# Altium schematic sheet IMX7S_CONNECTORS_&_IHM.SchDoc
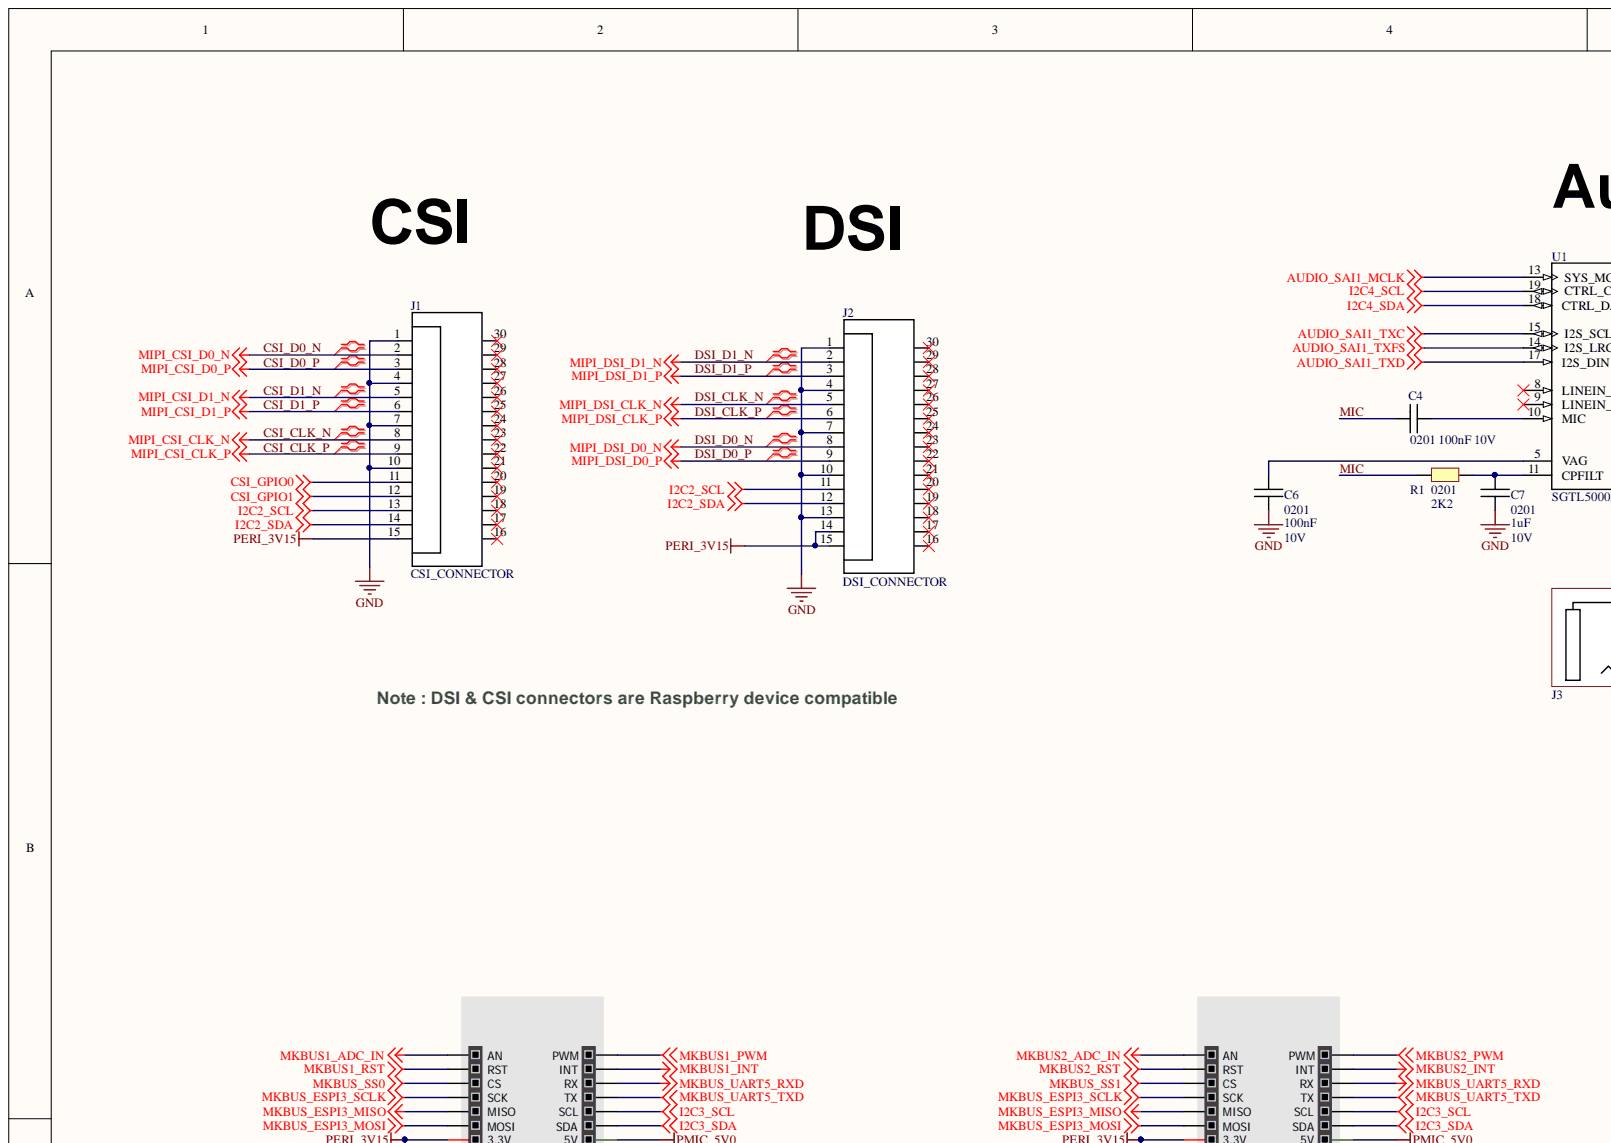
[diagram p0: IMX7S_CONNECTORS_&_IHM.SchDoc, top left]
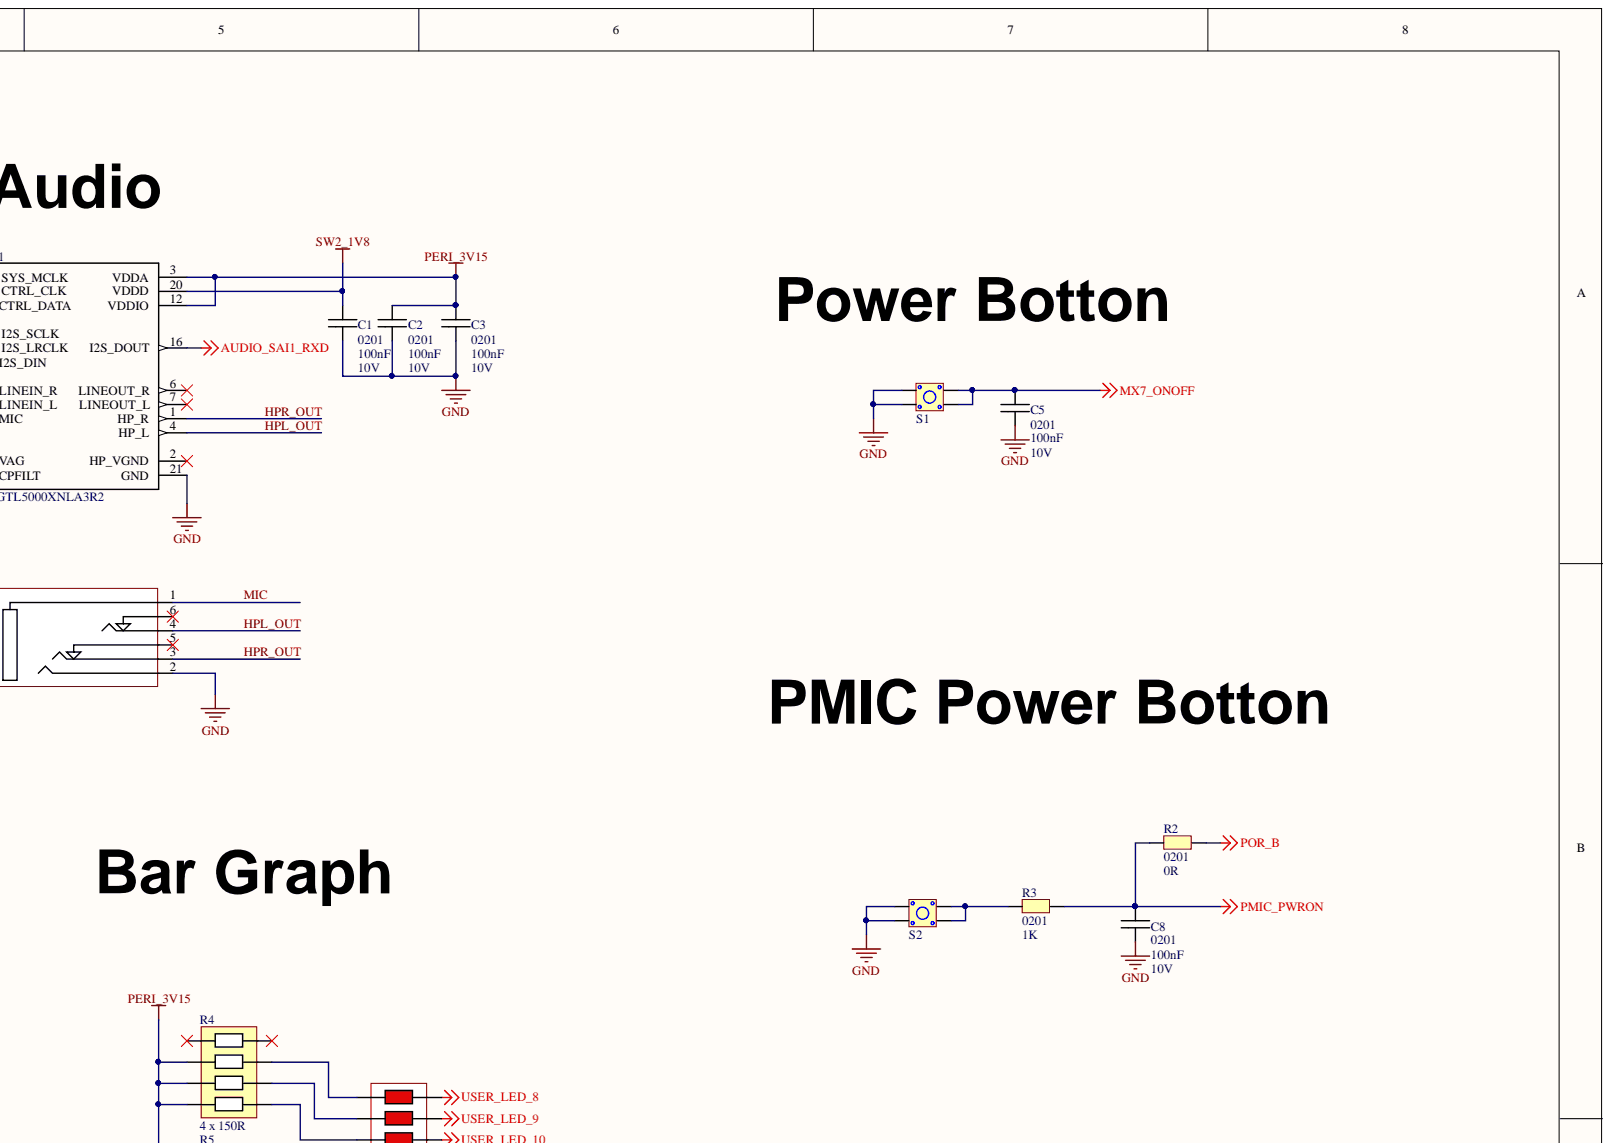
[diagram p1: IMX7S_CONNECTORS_&_IHM.SchDoc, top right]
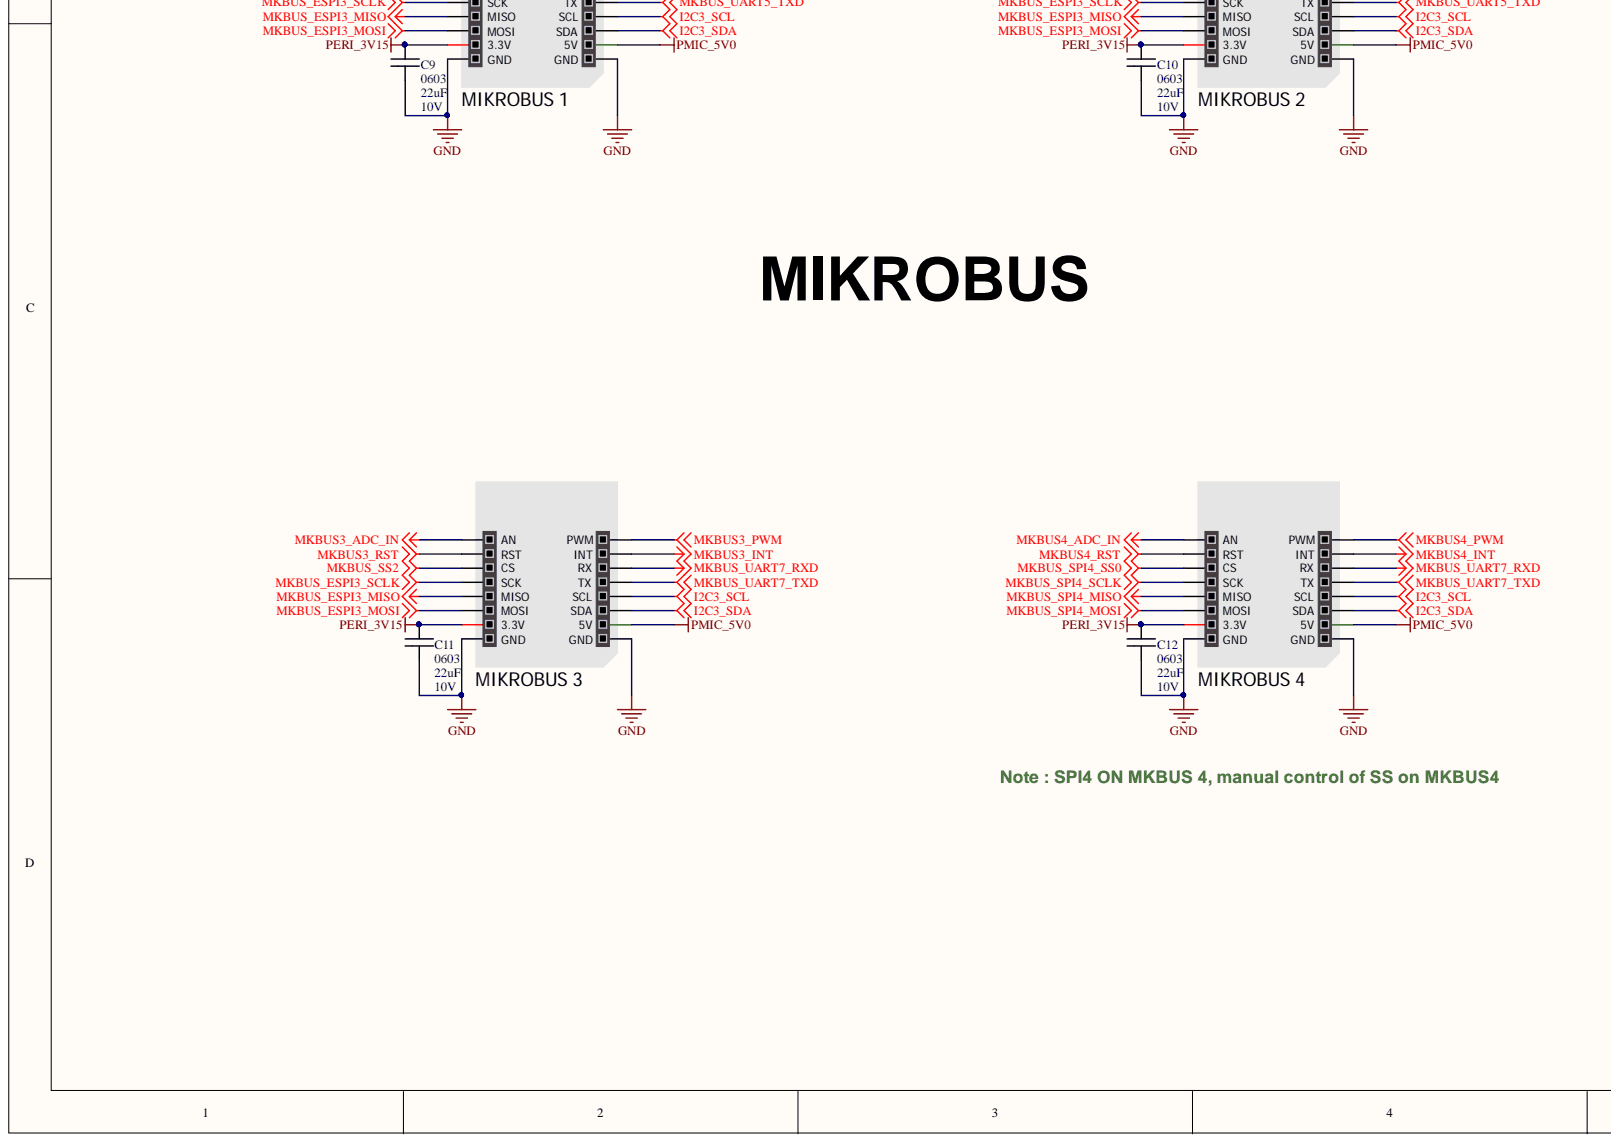
[diagram p2: IMX7S_CONNECTORS_&_IHM.SchDoc, bottom left]
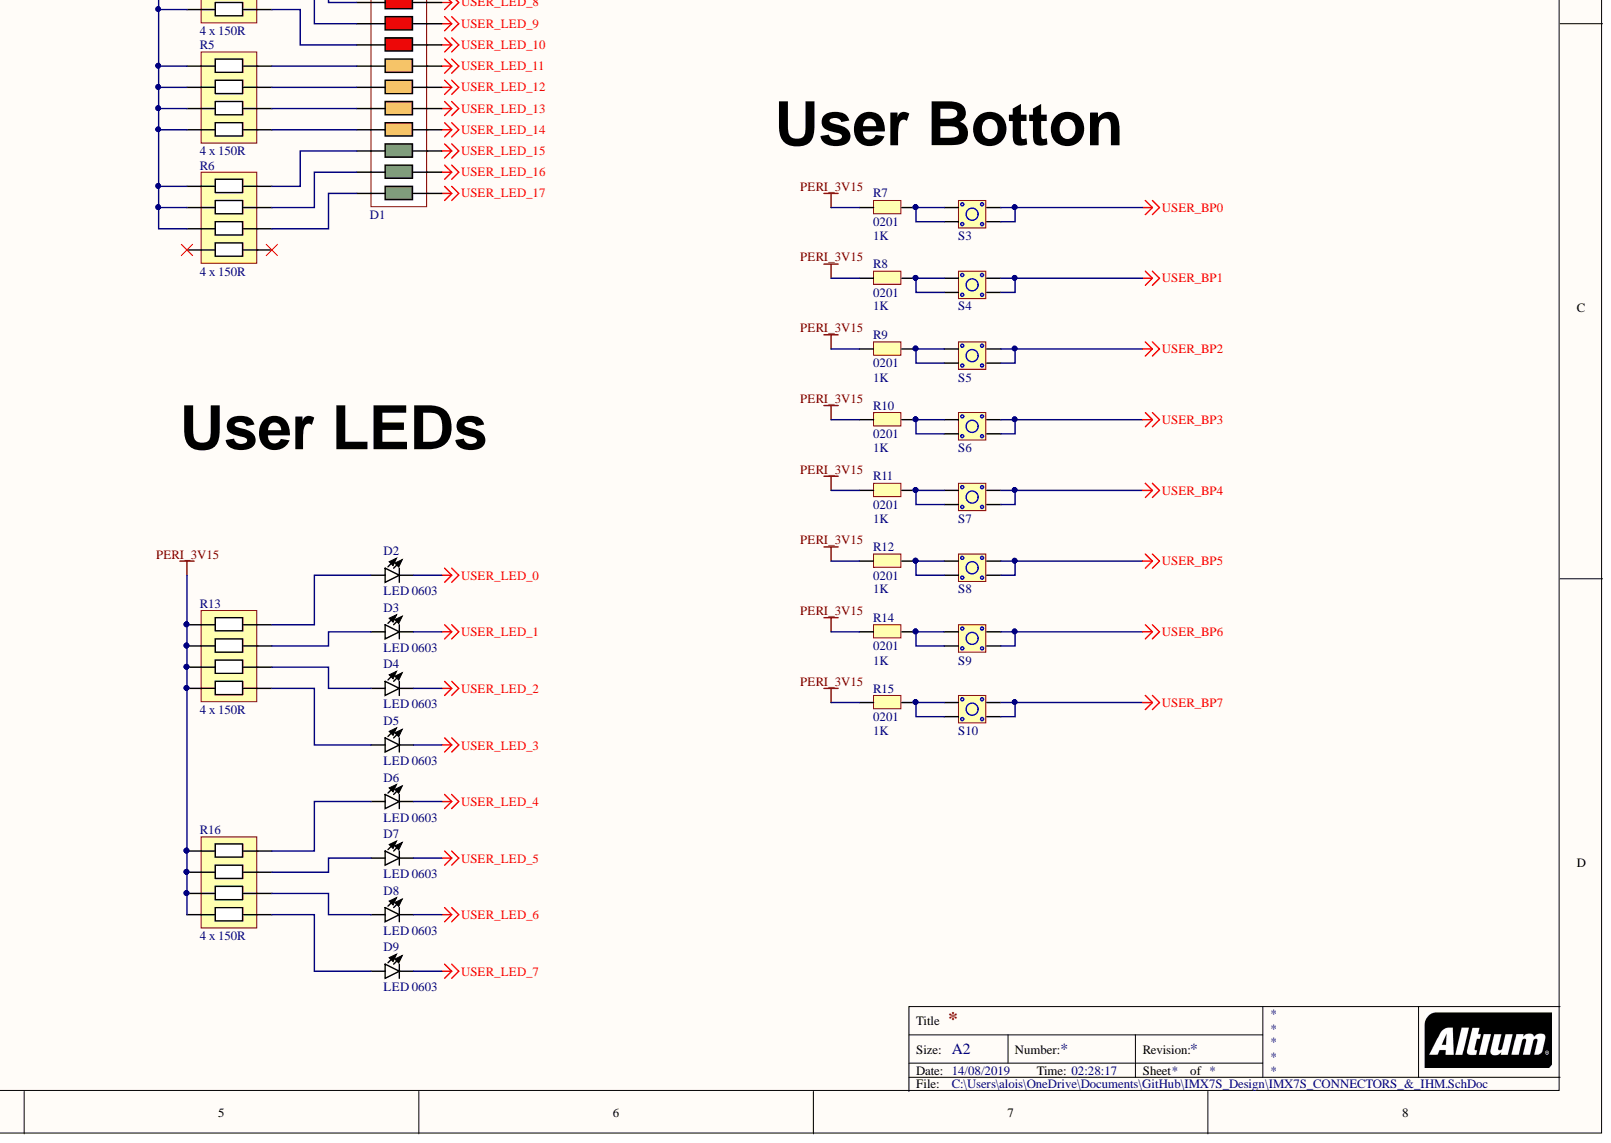
[diagram p3: IMX7S_CONNECTORS_&_IHM.SchDoc, bottom right]

## Parts
C1  value 100nF  PACKAGE: 0201  VOLTAGE: 10V  [C0201]  (hidden comment: C0201)
C2  value 100nF  PACKAGE: 0201  VOLTAGE: 10V  [C0201]  (hidden comment: C0201)
C3  value 100nF  PACKAGE: 0201  VOLTAGE: 10V  [C0201]  (hidden comment: C0201)
C4  C0201  value 100nF  PACKAGE: 0201  [C0201]
C5  value 100nF  PACKAGE: 0201  VOLTAGE: 10V  [C0201]  (hidden comment: C0201)
C6  value 100nF  PACKAGE: 0201  VOLTAGE: 10V  [C0201]  (hidden comment: C0201)
C7  value 1uF  PACKAGE: 0201  VOLTAGE: 10V  [C0201]  (hidden comment: C0201)
C8  value 100nF  PACKAGE: 0201  VOLTAGE: 10V  [C0201]  (hidden comment: C0201)
C9  value 22uF  PACKAGE: 0603  VOLTAGE: 10V  [C0603]  (hidden comment: C0603)
C10  value 22uF  PACKAGE: 0603  VOLTAGE: 10V  [C0603]  (hidden comment: C0603)
C11  value 22uF  PACKAGE: 0603  VOLTAGE: 10V  [C0603]  (hidden comment: C0603)
C12  value 22uF  PACKAGE: 0603  VOLTAGE: 10V  [C0603]  (hidden comment: C0603)
D1  BARGRAPH  [BARGRAPH]  (value not drawn)
D2  LED 0603  [D0603]
D3  LED 0603  [D0603]
D4  LED 0603  [D0603]
D5  LED 0603  [D0603]
D6  LED 0603  [D0603]
D7  LED 0603  [D0603]
D8  LED 0603  [D0603]
D9  LED 0603  [D0603]
J1  CSI_CONNECTOR  [CSI_DSI]
J2  DSI_CONNECTOR  [CSI_DSI]
J3  JACK_AUDIO  [JACK_AUDIO]  (value not drawn)
MIKROBUS_1  MIKROBUS HOST CONN.  [MIKROBUS HOST CONN.]  (value not drawn)
MIKROBUS_2  MIKROBUS HOST CONN.  [MIKROBUS HOST CONN.]  (value not drawn)
MIKROBUS_3  MIKROBUS HOST CONN.  [MIKROBUS HOST CONN.]  (value not drawn)
MIKROBUS_4  MIKROBUS HOST CONN.  [MIKROBUS HOST CONN.]  (value not drawn)
R1  value 2K2  PACKAGE: 0201  [R0201]  (hidden comment: R0201)
R2  R0201  value 0R  PACKAGE: 0201  [R0201]
R3  value 1K  PACKAGE: 0201  [R0201]  (hidden comment: R0201)
R4  4 x 150R  Resistance: 150R  [Reseau_R_1206]
R5  4 x 150R  Resistance: 150R  [Reseau_R_1206]
R6  4 x 150R  Resistance: 150R  [Reseau_R_1206]
R7  value 1K  PACKAGE: 0201  [R0201]  (hidden comment: R0201)
R8  value 1K  PACKAGE: 0201  [R0201]  (hidden comment: R0201)
R9  value 1K  PACKAGE: 0201  [R0201]  (hidden comment: R0201)
R10  value 1K  PACKAGE: 0201  [R0201]  (hidden comment: R0201)
R11  value 1K  PACKAGE: 0201  [R0201]  (hidden comment: R0201)
R12  value 1K  PACKAGE: 0201  [R0201]  (hidden comment: R0201)
R13  4 x 150R  Resistance: 150R  [Reseau_R_1206]
R14  value 1K  PACKAGE: 0201  [R0201]  (hidden comment: R0201)
R15  value 1K  PACKAGE: 0201  [R0201]  (hidden comment: R0201)
R16  4 x 150R  Resistance: 150R  [Reseau_R_1206]
S1  BP  [BP]  (value not drawn)
S2  BP  [BP]  (value not drawn)
S3  BP  [BP]  (value not drawn)
S4  BP  [BP]  (value not drawn)
S5  BP  [BP]  (value not drawn)
S6  BP  [BP]  (value not drawn)
S7  BP  [BP]  (value not drawn)
S8  BP  [BP]  (value not drawn)
S9  BP  [BP]  (value not drawn)
S10  BP  [BP]  (value not drawn)
U1  SGTL5000XNLA3R2  [QFN40P300X300X60-21N]

## Nets
AUDIO_SAI1_MCLK: U1.13(SYS_MCLK)
AUDIO_SAI1_RXD: U1.16(I2S_DOUT)
AUDIO_SAI1_TXC: U1.15(I2S_SCLK)
AUDIO_SAI1_TXD: U1.17(I2S_DIN)
AUDIO_SAI1_TXFS: U1.14(I2S_LRCLK)
CSI_GPIO0: J1.11
CSI_GPIO1: J1.12
GND: C1.1 C2.1 C3.1 C5.1 C6.1 C7.1 C8.1 C9.1 C10.1 C11.1 C12.1 J1.1 J1.4 J1.7 J1.10 J2.1 J2.4 J2.7 J2.10 J2.13 J3.2 MIKROBUS_1.8(GND) MIKROBUS_1.9(GND) MIKROBUS_2.8(GND) MIKROBUS_2.9(GND) MIKROBUS_3.8(GND) MIKROBUS_3.9(GND) MIKROBUS_4.8(GND) MIKROBUS_4.9(GND) S1.1 S1.2 S2.1 S2.2 U1.21(GND)
I2C2_SCL: J1.13 J2.11
I2C2_SDA: J1.14 J2.12
I2C3_SCL: MIKROBUS_1.12(SCL) MIKROBUS_2.12(SCL) MIKROBUS_3.12(SCL) MIKROBUS_4.12(SCL)
I2C3_SDA: MIKROBUS_1.11(SDA) MIKROBUS_2.11(SDA) MIKROBUS_3.11(SDA) MIKROBUS_4.11(SDA)
I2C4_SCL: U1.19(CTRL_CLK)
I2C4_SDA: U1.18(CTRL_DATA)
MKBUS1_ADC_IN: MIKROBUS_1.1(AN)
MKBUS1_INT: MIKROBUS_1.15(INT)
MKBUS1_PWM: MIKROBUS_1.16(PWM)
MKBUS1_RST: MIKROBUS_1.2(RST)
MKBUS2_ADC_IN: MIKROBUS_2.1(AN)
MKBUS2_INT: MIKROBUS_2.15(INT)
MKBUS2_PWM: MIKROBUS_2.16(PWM)
MKBUS2_RST: MIKROBUS_2.2(RST)
MKBUS3_ADC_IN: MIKROBUS_3.1(AN)
MKBUS3_INT: MIKROBUS_3.15(INT)
MKBUS3_PWM: MIKROBUS_3.16(PWM)
MKBUS3_RST: MIKROBUS_3.2(RST)
MKBUS4_ADC_IN: MIKROBUS_4.1(AN)
MKBUS4_INT: MIKROBUS_4.15(INT)
MKBUS4_PWM: MIKROBUS_4.16(PWM)
MKBUS4_RST: MIKROBUS_4.2(RST)
MKBUS_ESPI3_MISO: MIKROBUS_1.5(MISO) MIKROBUS_2.5(MISO) MIKROBUS_3.5(MISO)
MKBUS_ESPI3_MOSI: MIKROBUS_1.6(MOSI) MIKROBUS_2.6(MOSI) MIKROBUS_3.6(MOSI)
MKBUS_ESPI3_SCLK: MIKROBUS_1.4(SCK) MIKROBUS_2.4(SCK) MIKROBUS_3.4(SCK)
MKBUS_SPI4_MISO: MIKROBUS_4.5(MISO)
MKBUS_SPI4_MOSI: MIKROBUS_4.6(MOSI)
MKBUS_SPI4_SCLK: MIKROBUS_4.4(SCK)
MKBUS_SPI4_SS0: MIKROBUS_4.3(CS)
MKBUS_SS0: MIKROBUS_1.3(CS)
MKBUS_SS1: MIKROBUS_2.3(CS)
MKBUS_SS2: MIKROBUS_3.3(CS)
MKBUS_UART5_RXD: MIKROBUS_1.14(RX) MIKROBUS_2.14(RX)
MKBUS_UART5_TXD: MIKROBUS_1.13(TX) MIKROBUS_2.13(TX)
MKBUS_UART7_RXD: MIKROBUS_3.14(RX) MIKROBUS_4.14(RX)
MKBUS_UART7_TXD: MIKROBUS_3.13(TX) MIKROBUS_4.13(TX)
MX7_ONOFF: C5.2 S1.3 S1.4
PERI_3V15: C2.2 C3.2 C9.2 C10.2 C11.2 C12.2 J1.15 J2.14 J2.15 MIKROBUS_1.7(3.3V) MIKROBUS_2.7(3.3V) MIKROBUS_3.7(3.3V) MIKROBUS_4.7(3.3V) R4.2 R4.3 R4.4 R5.1 R5.2 R5.3 R5.4 R6.1 R6.2 R6.3 R7.1 R8.1 R9.1 R10.1 R11.1 R12.1 R13.1 R13.2 R13.3 R13.4 R14.1 R15.1 R16.1 R16.2 R16.3 R16.4 U1.3(VDDA) U1.12(VDDIO)
PMIC_5V0: MIKROBUS_1.10(5V) MIKROBUS_2.10(5V) MIKROBUS_3.10(5V) MIKROBUS_4.10(5V)
PMIC_PWRON: C8.2 R2.1 R3.2
POR_B: R2.2
SW2_1V8: C1.2 U1.20(VDDD)
USER_BP0: S3.3 S3.4
USER_BP1: S4.3 S4.4
USER_BP2: S5.3 S5.4
USER_BP3: S6.3 S6.4
USER_BP4: S7.3 S7.4
USER_BP5: S8.3 S8.4
USER_BP6: S9.3 S9.4
USER_BP7: S10.3 S10.4
USER_LED_0: D2.2
USER_LED_1: D3.2
USER_LED_2: D4.2
USER_LED_3: D5.2
USER_LED_4: D6.2
USER_LED_5: D7.2
USER_LED_6: D8.2
USER_LED_7: D9.2
USER_LED_8: D1.20
USER_LED_9: D1.19
USER_LED_10: D1.18
USER_LED_11: D1.17
USER_LED_12: D1.16
USER_LED_13: D1.15
USER_LED_14: D1.14
USER_LED_15: D1.13
USER_LED_16: D1.12
USER_LED_17: D1.11
CSI_CLK_N: J1.8
CSI_CLK_P: J1.9
CSI_D0_N: J1.2
CSI_D0_P: J1.3
CSI_D1_N: J1.5
CSI_D1_P: J1.6
DSI_CLK_N: J2.5
DSI_CLK_P: J2.6
DSI_D0_N: J2.8
DSI_D0_P: J2.9
DSI_D1_N: J2.2
DSI_D1_P: J2.3
HPL_OUT: J3.4 U1.4(HP_L)
HPR_OUT: J3.3 U1.1(HP_R)
MIC: C4.1 J3.1 R1.1
NetC4_2: C4.2 U1.10(MIC)
NetC6_2: C6.2 U1.5(VAG)
NetC7_2: C7.2 R1.2 U1.11(CPFILT)
NetD1_1: D1.1 R4.7
NetD1_2: D1.2 R4.6
NetD1_3: D1.3 R4.5
NetD1_4: D1.4 R5.8
NetD1_5: D1.5 R5.7
NetD1_6: D1.6 R5.6
NetD1_7: D1.7 R5.5
NetD1_8: D1.8 R6.8
NetD1_9: D1.9 R6.7
NetD1_10: D1.10 R6.6
NetD2_1: D2.1 R13.8
NetD3_1: D3.1 R13.7
NetD4_1: D4.1 R13.6
NetD5_1: D5.1 R13.5
NetD6_1: D6.1 R16.8
NetD7_1: D7.1 R16.7
NetD8_1: D8.1 R16.6
NetD9_1: D9.1 R16.5
NetR3_1: R3.1 S2.3 S2.4
NetR7_2: R7.2 S3.1 S3.2
NetR8_2: R8.2 S4.1 S4.2
NetR9_2: R9.2 S5.1 S5.2
NetR10_2: R10.2 S6.1 S6.2
NetR11_2: R11.2 S7.1 S7.2
NetR12_2: R12.2 S8.1 S8.2
NetR14_2: R14.2 S9.1 S9.2
NetR15_2: R15.2 S10.1 S10.2

Not connected: J1 16 17 18 19 20 21 22 23 24 25 26 27 28 29 30; J2 16 17 18 19 20 21 22 23 24 25 26 27 28 29 30; J3 5 6; R4 1 8; R6 4 5; U1 2(HP_VGND) 6(LINEOUT_R) 7(LINEOUT_L) 8(LINEIN_R) 9(LINEIN_L)

## Library data of the parts
C4 = C0201: VOLTAGE: 10V; Component Kind: Standard; Library Name: Schlib_IMX7.SchLib
D2-D9 = LED: Component Kind: Standard; Library Name: Schlib_IMX7.SchLib
J1 J2 = CSI_DSI_CONN: Component Kind: Standard; Library Name: Schlib_IMX7.SchLib
MIKROBUS_1-MIKROBUS_4 = MIKROBUS HOST CONN._1: mikroBUS Host Socket; Component Kind: Standard; Library Name: Schlib_IMX7.SchLib; SMD: No
R1-R3 R7-R12 R14 R15 = R0201: VOLTAGE: 0V; Component Kind: Standard; Library Name: Schlib_IMX7.SchLib
R4-R6 R13 R16 = Reseau_res: Manufacturer 1: Bourns; Manufacturer Part Number 1: CAY16-151J4LF; Circuit Type: Isolated; Number of Circuits: 4; Power Per Element: 62.5mW; Power Rating: 248mW; Temperature Coefficient: 200ppm/°C; Tolerance: 5%; Voltage Rating: 50V; Case/Package: 1206; Case Code (Imperial): 1206; Case Code (Metric): 3216; Component Kind: Standard; ComponentLink1Description: https://datasheet.ciiva.com/11807/0900766b8064e462-11807188.pdf?src-supplier=RSComponents; ComponentLink1URL: https://datasheet.ciiva.com/11807/0900766b8064e462-11807188.pdf?src-supplier=RSComponents; ComponentLink2Description: https://datasheet.ciiva.com/14583/70155479-14583402.pdf?src-supplier=Allied+Electronics; ComponentLink2URL: https://datasheet.ciiva.com/14583/70155479-14583402.pdf?src-supplier=Allied+Electronics; ComponentLink3Description: https://datasheet.ciiva.com/3264/catcay-3264859.pdf?src-supplier=Avnet; ComponentLink3URL: https://datasheet.ciiva.com/3264/catcay-3264859.pdf?src-supplier=Avnet; Contact Plating: Tin; Depth: 1.6mm; Height: 0.024inch; Length: 3.2mm; Library Name: Schlib_IMX7.SchLib; Max Operating Temperature: 125°C; Military Standard: Not; Min Operating Temperature: -55°C; Mount: Surface Mount; Number of Elements: 4; Number of Pins: 8; Number of Resistors: 4; Number of Terminals: 8; Package Quantity: 5000; Packaging: Tape and Reel; Pricing 1: 10=0,06620, 100=0,05150, 500=0,04090, 1000=0,03560, 2500=0,03160, 5000=0,02900, 25000=0,02070 (EUR); Radiation Hardening: No; REACH SVHC: No SVHC; RoHS: Yes; Stock 1: 11414; Supplier 1: Farnell; Supplier Part Number 1: 2321741; Terminal Pitch: 0.8mm; Termination: Convex; Voltage Rating (DC): 50V; Width: 0.063inch
S1-S10 = BP: Manufacturer: TE Connectivity (Tyco Electronics); Manufacturer Part Number: 1-1825027-4; Actuator Color: Ivory; Actuator Length: 3.55 mm; Actuator Style: Round Button; Body Orientation: Right Angle; Contact Rating @ Voltage: 0.05@24VDC A; Dielectric Strength: 500 VAC; Electrical Life: 100000 Cycles; Ground Terminal: No; Illumination Type: N/R; Maximum Contact Resistance: 100 mOhm; Maximum DC Voltage Rating: 24 V; Operating Force: 1.57 N; Pole Throw Configuration: SPST; Switch Configuration: OFF (ON); Base Material: N/A; Component Kind: Standard; ComponentLink1Description: https://datasheet.ciiva.com/8164/eng-cd-1825027-g4-8164816.pdf; ComponentLink1URL: https://datasheet.ciiva.com/8164/eng-cd-1825027-g4-8164816.pdf; ComponentLink2Description: https://datasheet.ciiva.com/17550/eng-cd-1825027-g4-17550604.pdf; ComponentLink2URL: https://datasheet.ciiva.com/17550/eng-cd-1825027-g4-17550604.pdf; Contact Material: Copper Alloy; Contact Plating: Silver; Dimension: 7.11 x 10.16 x 7.01 mm; Family: 1825027; Housing Material: N/A; Library Name: Schlib_IMX7.SchLib; Maximum AC Voltage Rating: N/A; Mechanical Life: N/A; Minimum Insulation Resistance: 100 MOhm; Mounting: Through Hole,PC Pins; Operating Temperature: -35 to 85 C; Packaging: Loose Piece; Part No: 1-1825027-4; Product Weight: N/A; RoHS: Yes; Seal Description: N/A; Seal Location: N/A; Type: Micro-Miniature
U1 = SGTL5000XNLA3R2: Audio Codec , Ultra Low-Power, 4 mw for DAC-to-HP, 98 dB SNR and -80 dB THD+N, QFN 32, Reel; MF: NXP USA; Component Kind: Standard; Digi-Key_Part_Number: 568-13255-1-ND; Digi-Key_Purchase_URL: https://www.digikey.com/product-detail/en/nxp-usa-inc/SGTL5000XNLA3R2/568-13255-1-ND/6576159?utm_source=snapeda&utm_medium=aggregator&utm_campaign=symbol; Library Name: Schlib_IMX7.SchLib; MP: SGTL5000XNLA3R2; Package: QFN-20 NXP Semiconductors; SnapEDA_Link: https://www.snapeda.com/parts/SGTL5000XNLA3R2/NXP%20USA%20Inc./view-part/?ref=snap
C1-C3 C5-C8 = C0201: Component Kind: Standard; Library Name: Schlib_IMX7.SchLib
C9-C12 = C0603: Component Kind: Standard; Library Name: Schlib_IMX7.SchLib
D1 = BARGRAPH: Component Kind: Standard; Library Name: Schlib_IMX7.SchLib
J3 = JACK_AUDIO: Component Kind: Standard; Library Name: Schlib_IMX7.SchLib; Supplier 1: Farnell; Supplier Part Number 1: 2613992
